# Altium schematic sheet ГРЛМ.468332.035 Э3.SchDoc
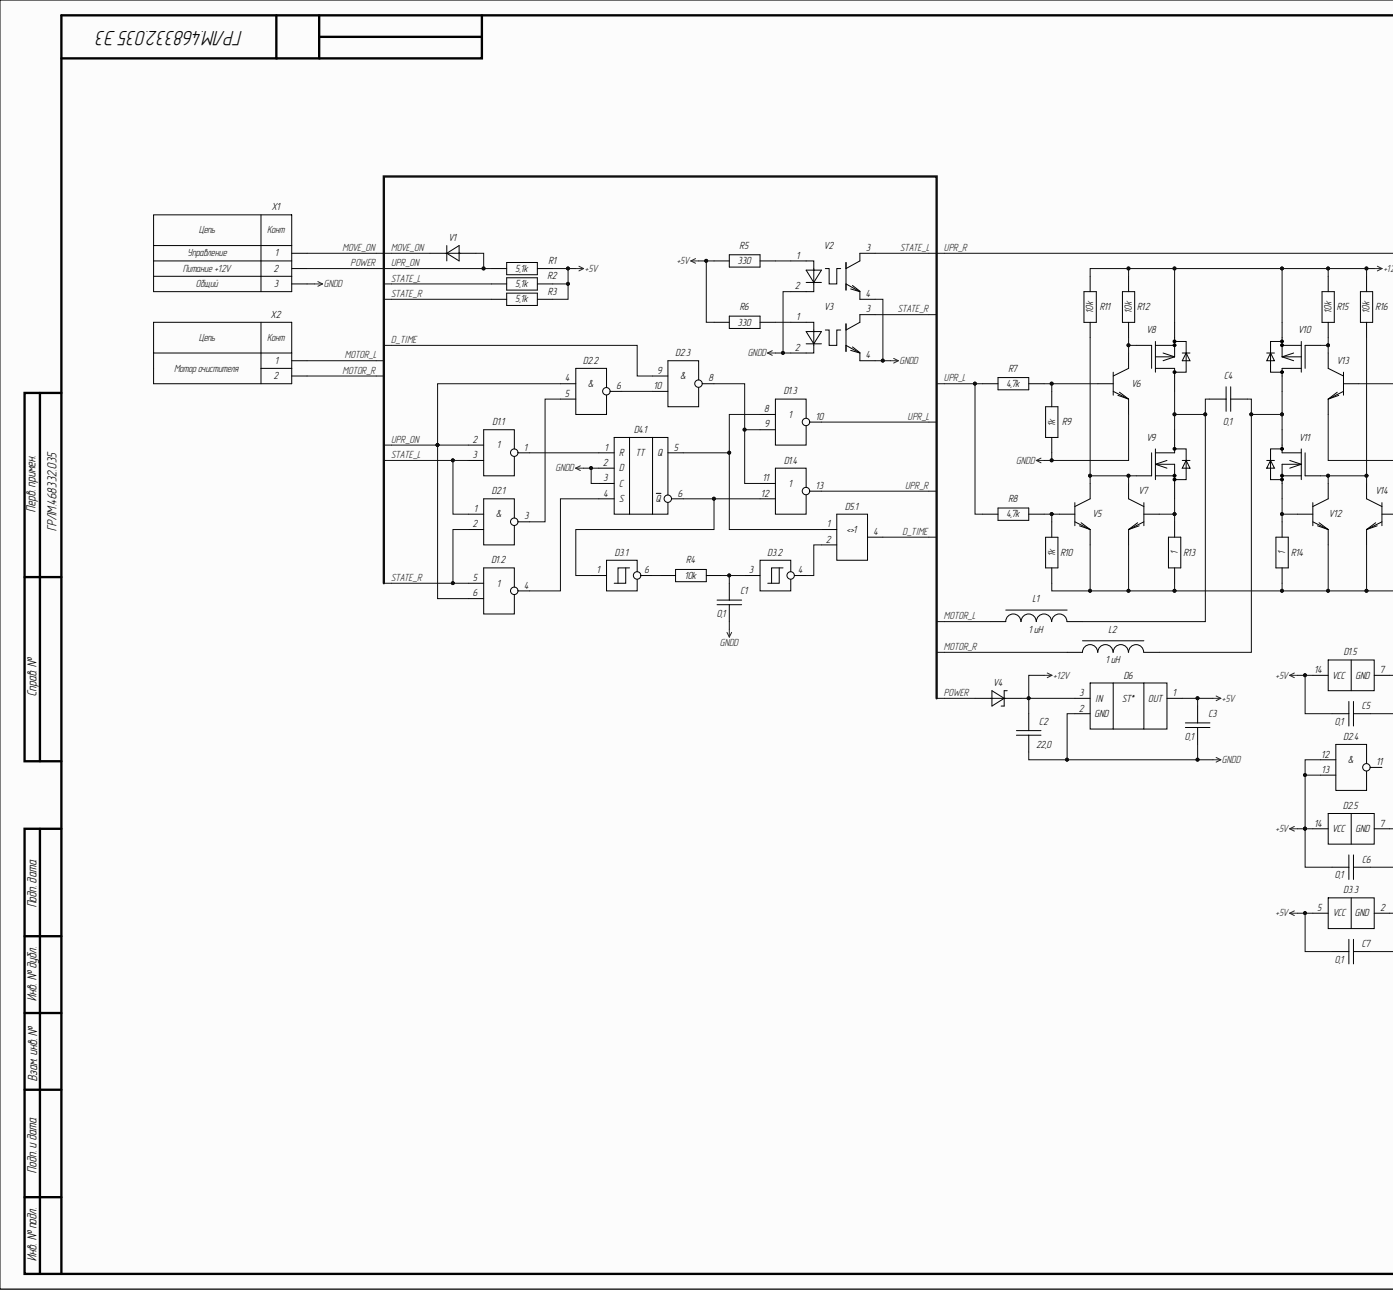
[diagram p0: ГРЛМ.468332.035 Э3.SchDoc, left]
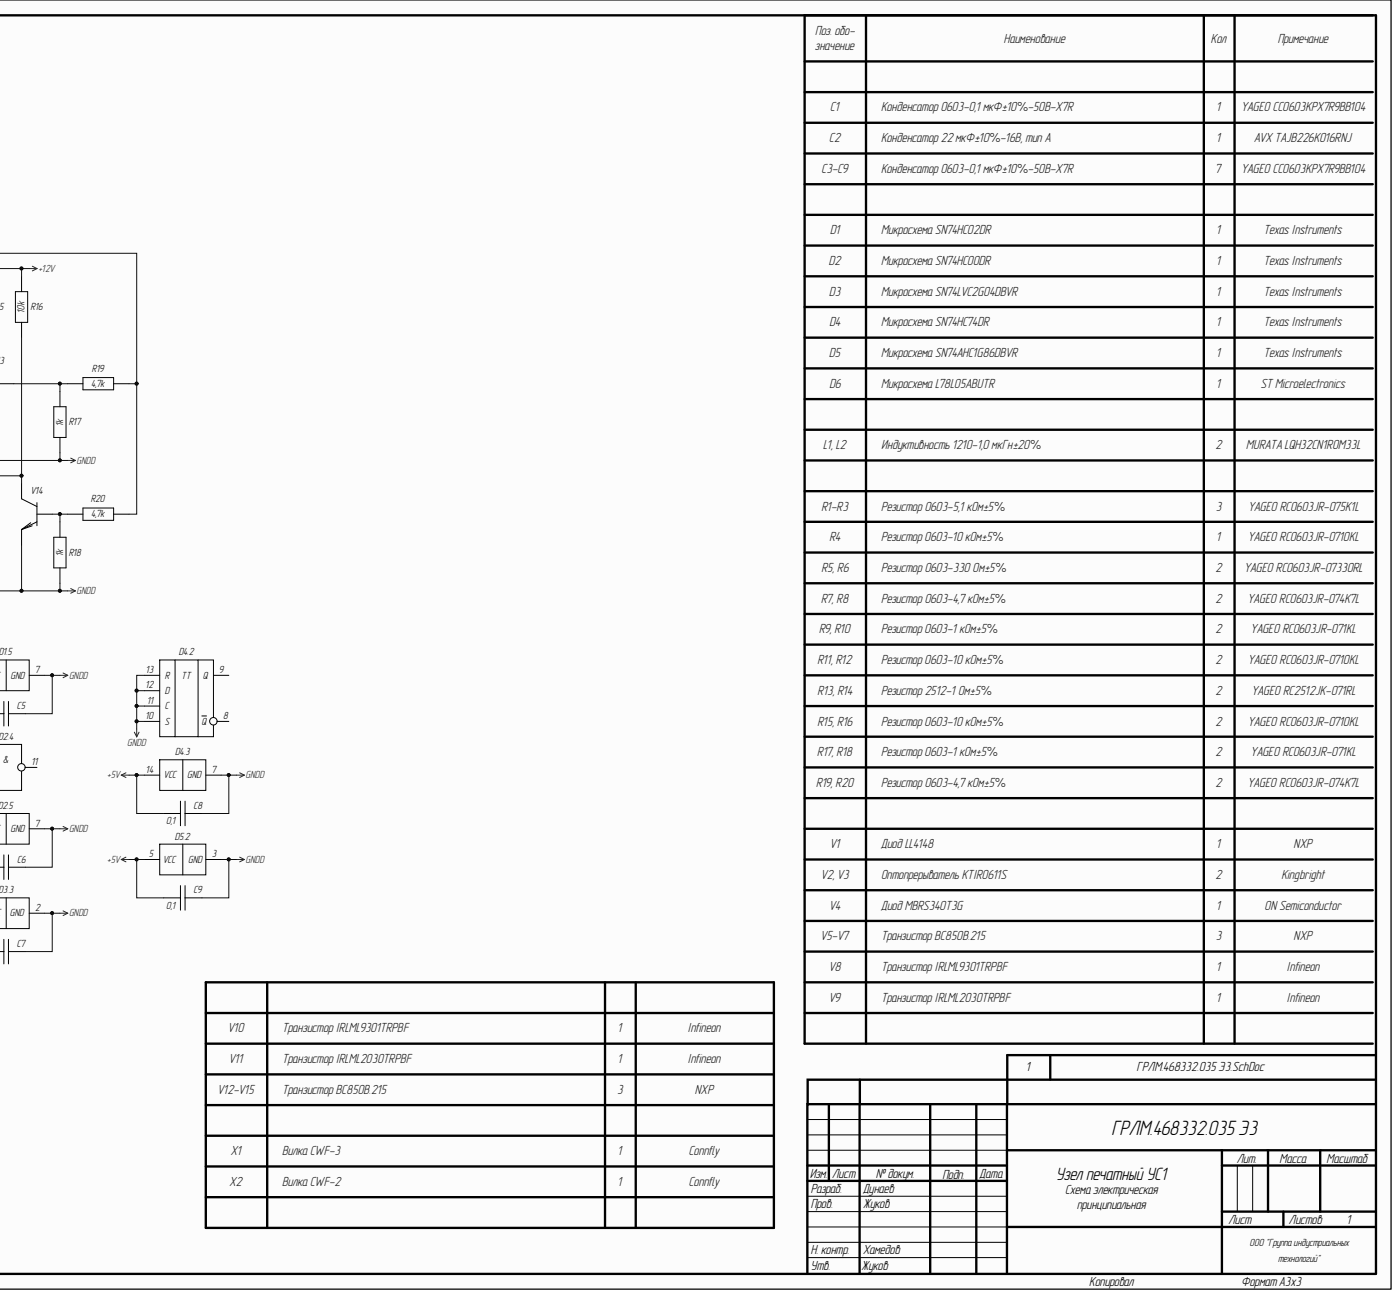
[diagram p1: ГРЛМ.468332.035 Э3.SchDoc, right]

## Parts
C1  value 0,1  [CAP0603]  (hidden comment: CERCAP_0603)
C2  value 22,0  [CAP1206]  (hidden comment: CERCAP_1206)
C3  value 0,1  [CAP0603]  (hidden comment: CERCAP_0603)
C4  value 0,1  [CAP0603]  (hidden comment: CERCAP_0603)
C5  value 0,1  [CAP0603]  (hidden comment: CERCAP_0603)
C6  value 0,1  [CAP0603]  (hidden comment: CERCAP_0603)
C7  value 0,1  [CAP0603]  (hidden comment: CERCAP_0603)
C8  value 0,1  [CAP0603]  (hidden comment: CERCAP_0603)
C9  value 0,1  [CAP0603]  (hidden comment: CERCAP_0603)
D1  SN74HC02DR  [SN74HC02DR]  (parts .1,.2,.3,.4,.5)
D2  SN74HC00DR  [SN74HC00DR]  (parts .1,.2,.3,.4,.5)
D3  SN74LVC2G14DBVR  [SN74LVC2G14DBVR]  (value not drawn)  (parts .1,.2,.3)
D4  SN74HC74DR  [SN74HC74DR]  (parts .1,.2,.3)
D5  SN74AHC1G86DBVR  [SN74AHC1G86DBVR]  (parts .1,.2)
D6  L78L05ABUTR  [L78L05ABUTR]
L1  LQH32CN1R0M33L  value 1 uH  [LQH]
L2  LQH32CN1R0M33L  value 1 uH  [LQH]
R1  value 5,1k  [RES0603]  (hidden comment: RES_0603)
R2  value 5,1k  [RES0603]  (hidden comment: RES_0603)
R3  value 5,1k  [RES0603]  (hidden comment: RES_0603)
R4  value 10k  [RES0603]  (hidden comment: RES_0603)
R5  value 330  [RES0603]  (hidden comment: RES_0603)
R6  value 330  [RES0603]  (hidden comment: RES_0603)
R7  value 4,7k  [RES0603]  (hidden comment: RES_0603)
R8  value 4,7k  [RES0603]  (hidden comment: RES_0603)
R9  value 1k  [RES0603]  (hidden comment: RES_0603)
R10  value 1k  [RES0603]  (hidden comment: RES_0603)
R11  value 10k  [RES0603]  (hidden comment: RES_0603)
R12  value 10k  [RES0603]  (hidden comment: RES_0603)
R13  value 1  [RES2512]  (hidden comment: RES_2512)
R14  value 1  [RES2512]  (hidden comment: RES_2512)
R15  value 10k  [RES0603]  (hidden comment: RES_0603)
R16  value 10k  [RES0603]  (hidden comment: RES_0603)
R17  value 1k  [RES0603]  (hidden comment: RES_0603)
R18  value 1k  [RES0603]  (hidden comment: RES_0603)
R19  value 4,7k  [RES0603]  (hidden comment: RES_0603)
R20  value 4,7k  [RES0603]  (hidden comment: RES_0603)
V1  LL4148  [LL4148]  (value not drawn)
V2  KTIR0611S  [KTIR0611S]
V3  KTIR0611S  [KTIR0611S]
V4  MBRS340T3G  [MBRS340T3G]
V5  BC850B  [BC850B.215]  (value not drawn)
V6  BC850B  [BC850B.215]  (value not drawn)
V7  BC850B  [BC850B.215]  (value not drawn)
V8  IRLML9301TRPBF  [IRLML9301TRPBF]
V9  IRLML2030TRPBF  [IRLML2030TRPBF]
V10  IRLML9301TRPBF  [IRLML9301TRPBF]
V11  IRLML2030TRPBF  [IRLML2030TRPBF]
V12  BC850B  [BC850B.215]  (value not drawn)
V13  BC850B  [BC850B.215]  (value not drawn)
V14  BC850B  [BC850B.215]  (value not drawn)
X1  CWF-3  [CWF-3]  (value not drawn)
X2  CWF-2  [CWF-2]  (value not drawn)

## Nets
+5V: C3.1 C5.2 C6.2 C7.2 C8.2 C9.2 D1.14(VCC) D2.12(&) D2.13 D2.14(VCC) D3.5(VCC) D4.14(VCC) D5.5(VCC) D6.1 R1.1 R2.1 R3.1 R5.2 R6.2
+12V: C2.1 D6.3 R11.1 R12.1 R15.1 R16.1 V4.1 V8.2 V10.2
GNDD: C1.2 C2.2 C3.2 C5.1 C6.1 C7.1 C8.1 C9.1 D1.7(GND) D2.7(GND) D3.2(GND) D4.2(D) D4.3(C) D4.7(GND) D4.10(S) D4.11(C) D4.12(D) D4.13(R) D5.3(GND) D6.2 R9.2 R10.2 R13.1 R14.1 R17.2 R18.2 V2.2 V2.4 V3.2 V3.4 V5.2 V6.2 V7.2 V12.2 V13.2 V14.2 X1.3
D_TIME: D2.9(&) D5.4
MOTOR_L: L1.1 X2.1
MOTOR_R: L2.1 X2.2
MOVE_ON: V1.1 X1.1
POWER: V4.2 X1.2
STATE_L: D1.3 D2.1(&) R2.2 V2.3
STATE_R: D1.5 D2.2 R3.2 V3.3
UPR_L: D1.10 R7.2 R8.2
UPR_ON: D1.2 D1.6 D2.4(&) R1.2 V1.2
UPR_R: D1.13 R19.1 R20.1
NetC1_1: C1.1 D3.3 R4.1
NetC4_1: C4.1 L2.2 V10.3 V11.3
NetC4_2: C4.2 L1.2 V8.3 V9.3
NetD1_1: D1.1 D4.1(R)
NetD1_4: D1.4 D4.4(S)
NetD1_8: D1.8 D4.5(Q) D5.1(<>1)
NetD1_9: D1.9 D1.11 D2.8
NetD1_12: D1.12 D3.1 D4.6(Q)
NetD2_3: D2.3 D2.5
NetD2_6: D2.6 D2.10
NetD3_4: D3.4 D5.2
NetD3_6: D3.6 R4.2
NetR5_1: R5.1 V2.1
NetR6_1: R6.1 V3.1
NetR7_1: R7.1 R9.1 V6.1
NetR8_1: R8.1 R10.1 V5.1
NetR11_2: R11.2 V5.3 V7.3 V9.1
NetR12_2: R12.2 V6.3 V8.1
NetR13_2: R13.2 V7.1 V9.2
NetR14_2: R14.2 V11.2 V12.1
NetR15_2: R15.2 V10.1 V13.3
NetR16_2: R16.2 V11.1 V12.3 V14.3
NetR17_1: R17.1 R19.2 V13.1
NetR18_1: R18.1 R20.2 V14.1
N00008:

Not connected: D2 11; D4 8(Q) 9(Q)

## Library data of the parts
C1 C3-C9 = CERCAP_0603: Конденсатор керамический; Type: CC0603KPX7R9BB104; Артикул: арт. CC0603KPX7R9BB104; Наименование: 0603-0,1 мкФ±10%-50В-X7R; Производитель: YAGEO; Component Kind: Standard; Operating temperature: -55 °C...125 °C; Library Name: Конденсаторы.SchLib
C2 = CERCAP_1206: Конденсатор керамический; Type: CC1206KKX5R7BB226; Артикул: арт. CC1206KKX5R7BB226; Наименование: 1206-22 мкФ±10%-16В-X5R; Производитель: YAGEO; Component Kind: Standard; Operating temperature: -55 °C...85 °C; Library Name: Конденсаторы.SchLib
D1 = SN74HC02DR: 4 х 2ИЛИ-НЕ ТТЛ; Производитель: Texas Instruments; Component Kind: Standard; Operating temperature: -55 °C...125 °C; Library Name: Микросхемы.SchLib
D2 = SN74HC00DR: 4 х 2И-НЕ ТТЛ; Производитель: Texas Instruments; Component Kind: Standard; Operating temperature: -55 °C...125 °C; Library Name: Микросхемы.SchLib
D3 = SN74LVC2G14DBVR: 2 х НЕ Шмидт; Component Kind: Standard; Library Name: Микросхемы.SchLib
D4 = SN74HC74DR: 2 D-триггера; Component Kind: Standard; Library Name: Микросхемы.SchLib
D5 = SN74AHC1G86DBVR: 1 х 2Искл_ИЛИ; Производитель: Texas Instruments; Component Kind: Standard; Operating temperature: -40 °C...125 °C; Library Name: Микросхемы.SchLib
D6 = L78L05ABUTR: Стабилизатор 5В; Производитель: ST Microelectronics; Component Kind: Standard; Operating temperature: -40 °C...125 °C; Library Name: Микросхемы.SchLib
L1 L2 = LQH32CN1R0M33L: Дроссель ВЧ; Производитель: Murata; Component Kind: Standard; Operating temperature: -40 °C...85 °C; Library Name: Трансформаторы_Дроссели.SchLib
R1-R3 = RES_0603: Резистор SMD; Артикул: арт. RC0603JR-075K1L; Наименование: 0603-5,1 кОм±5%; Производитель: YAGEO; Component Kind: Standard; Operating temperature: -55 °C...125 °C; Type: RC0603JR-075K1L; Library Name: Резисторы.SchLib
R4 R11 R12 R15 R16 = RES_0603: Резистор SMD; Артикул: арт. RC0603JR-0710KL; Наименование: 0603-10 кОм±5%; Производитель: YAGEO; Component Kind: Standard; Operating temperature: -55 °C...125 °C; Type: RC0603JR-0710KL; Library Name: Резисторы.SchLib
R5 R6 = RES_0603: Резистор SMD; Артикул: арт. RC0603JR-07330RL; Наименование: 0603-330 Ом±5%; Производитель: YAGEO; Component Kind: Standard; Operating temperature: -55 °C...125 °C; Type: RC0603JR-07330RL; Library Name: Резисторы.SchLib
R7 R8 R19 R20 = RES_0603: Резистор SMD; Артикул: арт. RC0603JR-074K7L; Наименование: 0603-4,7 кОм±5%; Производитель: YAGEO; Component Kind: Standard; Operating temperature: -55 °C...125 °C; Type: RC0603JR-074K7L; Library Name: Резисторы.SchLib
R9 R10 R17 R18 = RES_0603: Резистор SMD; Артикул: арт. RC0603JR-071KL; Наименование: 0603-1 кОм±5%; Производитель: YAGEO; Component Kind: Standard; Operating temperature: -55 °C...125 °C; Type: RC0603JR-071KL; Library Name: Резисторы.SchLib
R13 R14 = RES_2512: Резистор SMD; Component Kind: Standard; Library Name: Резисторы.SchLib
V1 = LL4148: Диод выпрямительный; Производитель: NXP; Component Kind: Standard; Operating temperature: -65 °C...175 °C; Library Name: Полупроводники.SchLib
V2 V3 = KTIR0611S: Оптопрерыватель; Производитель: Kingbright; Component Kind: Standard; Operating temperature: -25 °C...85 °C; Library Name: Полупроводники.SchLib
V4 = MBRS340T3G: Диод Шоттки; Component Kind: Standard; Library Name: Полупроводники.SchLib
V5-V7 V12-V14 = BC850B: Транзистор NPN; Производитель: NXP; Component Kind: Standard; Operating temperature: -65 °C...150 °C; Library Name: Транзисторы.SchLib
V8 V10 = IRLML9301TRPBF: Транзистор P-MOSFET 30В 3,6А; Производитель: Infineon; Component Kind: Standard; Operating temperature: -55 °C...150 °C; Library Name: Транзисторы.SchLib
V9 V11 = IRLML2030TRPBF: Транзистор N-MOSFET 30В 2,7А; Производитель: Infineon; Component Kind: Standard; Operating temperature: -55 °C...150 °C; Library Name: Транзисторы.SchLib
X1 = CWF-3: Вилка; Производитель: Connfly; Component Kind: Standard; Operating temperature: -25 °C...85 °C; Library Name: Соединители.SchLib
X2 = CWF-2: Вилка; Производитель: Connfly; Component Kind: Standard; Operating temperature: -25 °C...85 °C; Library Name: Соединители.SchLib
